# Altium schematic sheet Indicators.SchDoc(Indicators)
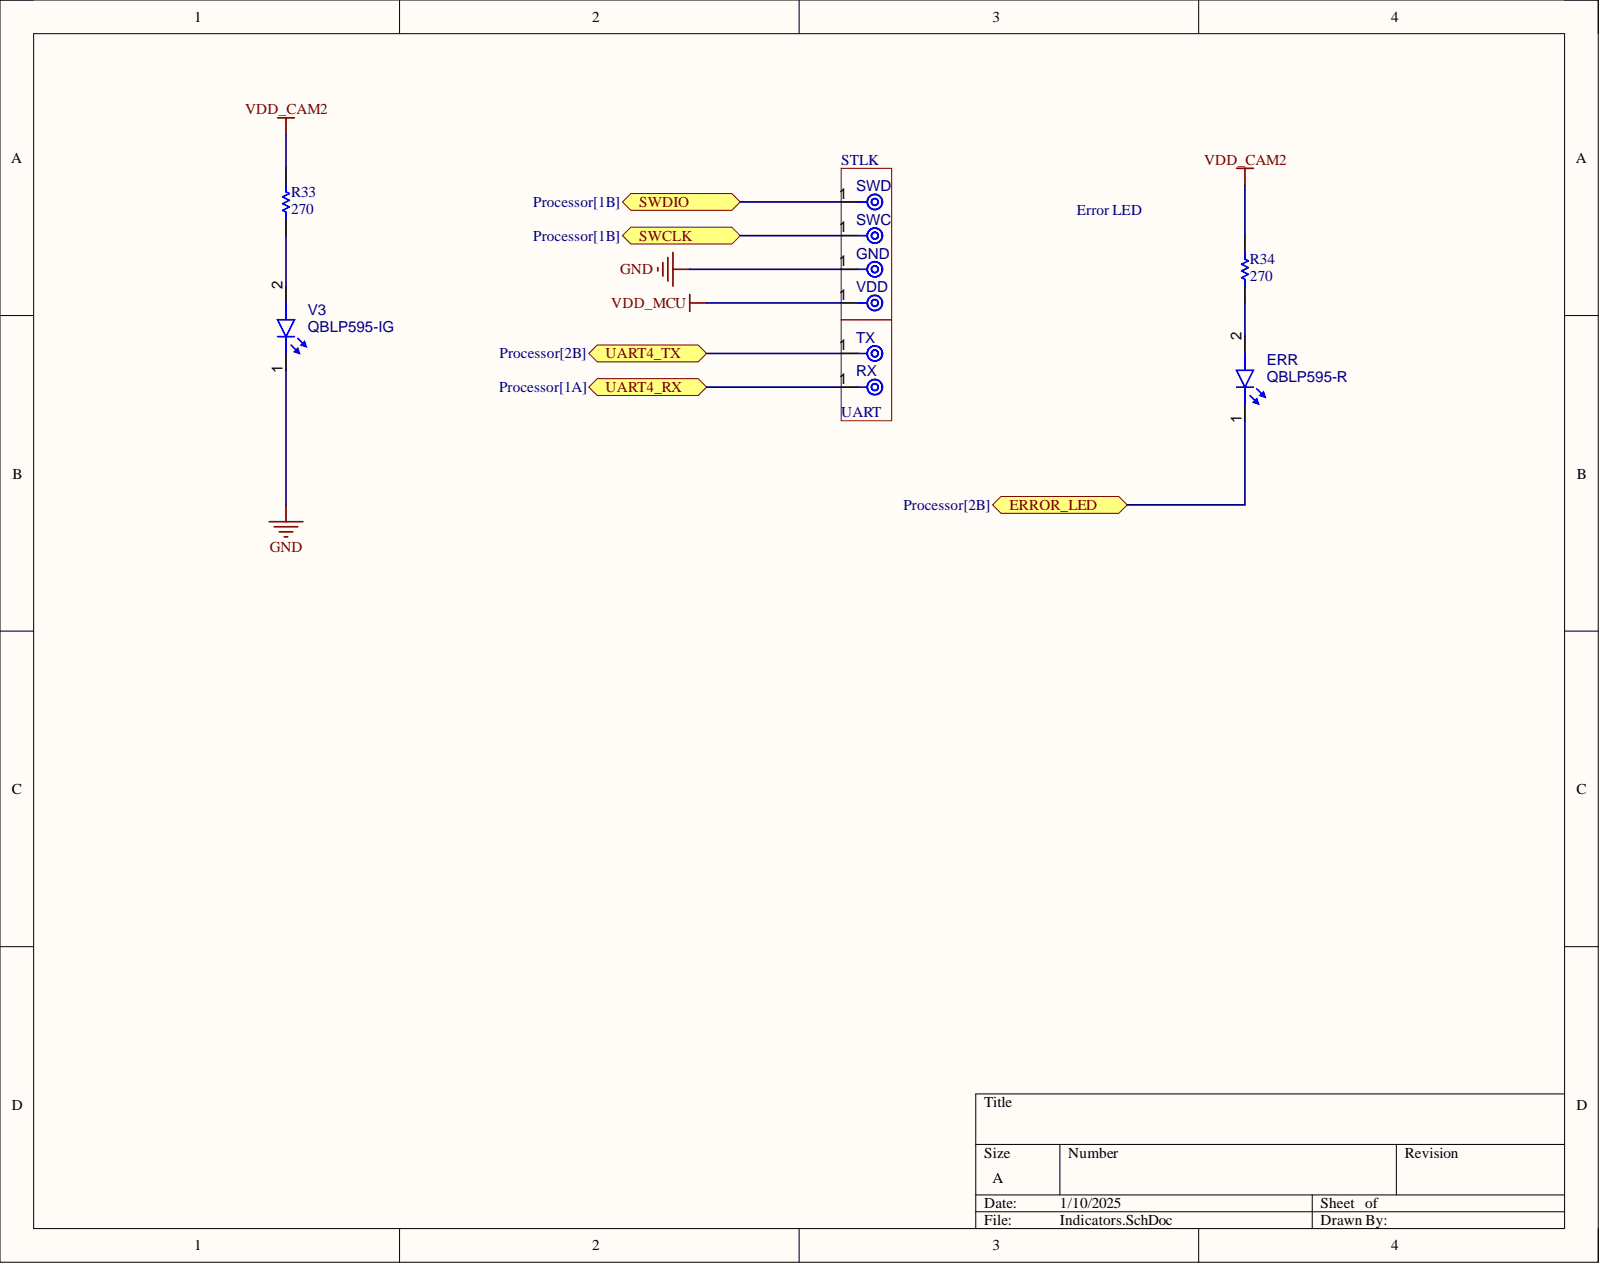
[diagram p0: Indicators.SchDoc]

## Parts
ERR  QBLP595-R
GND  TP_16AWG  (value not drawn)  (no BOM)
R33  270
R34  270
RX  TP_16AWG  (value not drawn)  (no BOM)
SWC  TP_16AWG  (value not drawn)  (no BOM)
SWD  TP_16AWG  (value not drawn)  (no BOM)
TX  TP_16AWG  (value not drawn)  (no BOM)
V3  QBLP595-IG
VDD  TP_16AWG  (value not drawn)  (no BOM)

## Ports
ERROR_LED, SWCLK, SWDIO, UART4_RX, UART4_TX

## Nets
GND: GND.1 V3.1
VDD_CAM2: R33.2 R34.2
VDD_MCU: VDD.1
ERROR_LED: ERR.1 ; port ERROR_LED
SWCLK: SWC.1 ; port SWCLK
SWDIO: SWD.1 ; port SWDIO
UART4_RX: RX.1 ; port UART4_RX
UART4_TX: TX.1 ; port UART4_TX
NetERR_2: ERR.2 R34.1
NetR33_1: R33.1 V3.2

## Library data of the parts
ERR = CMP-007-00040-3: Chip LED 0402, Red, 0.02 A, 2.0 to 2.5 V, -40 to 80 degC, 2-Pin SMD, RoHS, Tape and Reel; Case/Package: 0402; Colour: Red; Component Kind: Standard; Mounting Technology: SMT; Pins: 2; RoHS Compliant: Yes; Library Name: Openwater
GND RX SWC SWD TX VDD = CMP-00028-00007-1: test point for 16 gauge wire input; Pins: 1; Library Name: Openwater
R33 R34 = CMP-2002-07003-1: Manufacturer: Yageo / Phycomp; Part Number: RC0402FR-07270RL; Component Kind: Standard; Library Name: Altium Content Vault
V3 = CMP-007-00041-3: Chip LED 0402, Green, 0.02 A, 3.1 to 3.7 V, -40 to 80 degC, 2-Pin SMD, RoHS, Tape and Reel; Case/Package: 0402; Colour: Green; Component Kind: Standard; Mounting Technology: SMT; Pins: 2; RoHS Compliant: Yes; Library Name: Openwater
